# Altium schematic sheet LM25119_EVB.SchDoc(LM25119_EVB)
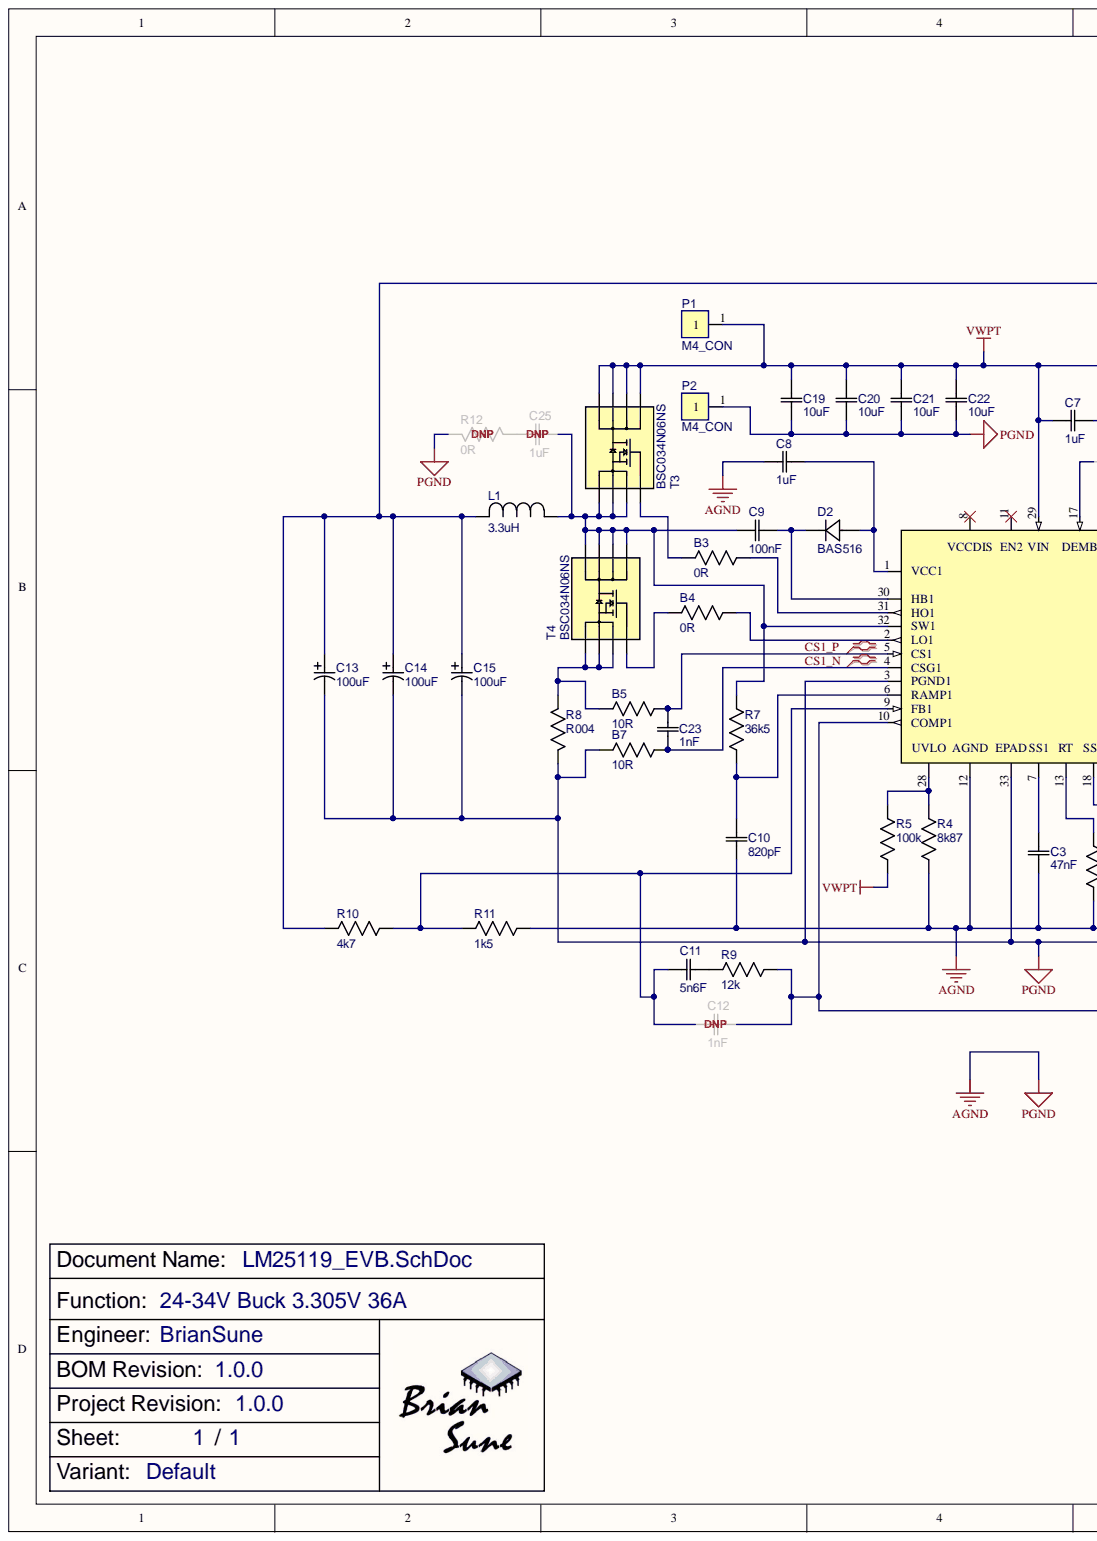
[diagram p0: LM25119_EVB.SchDoc, left]
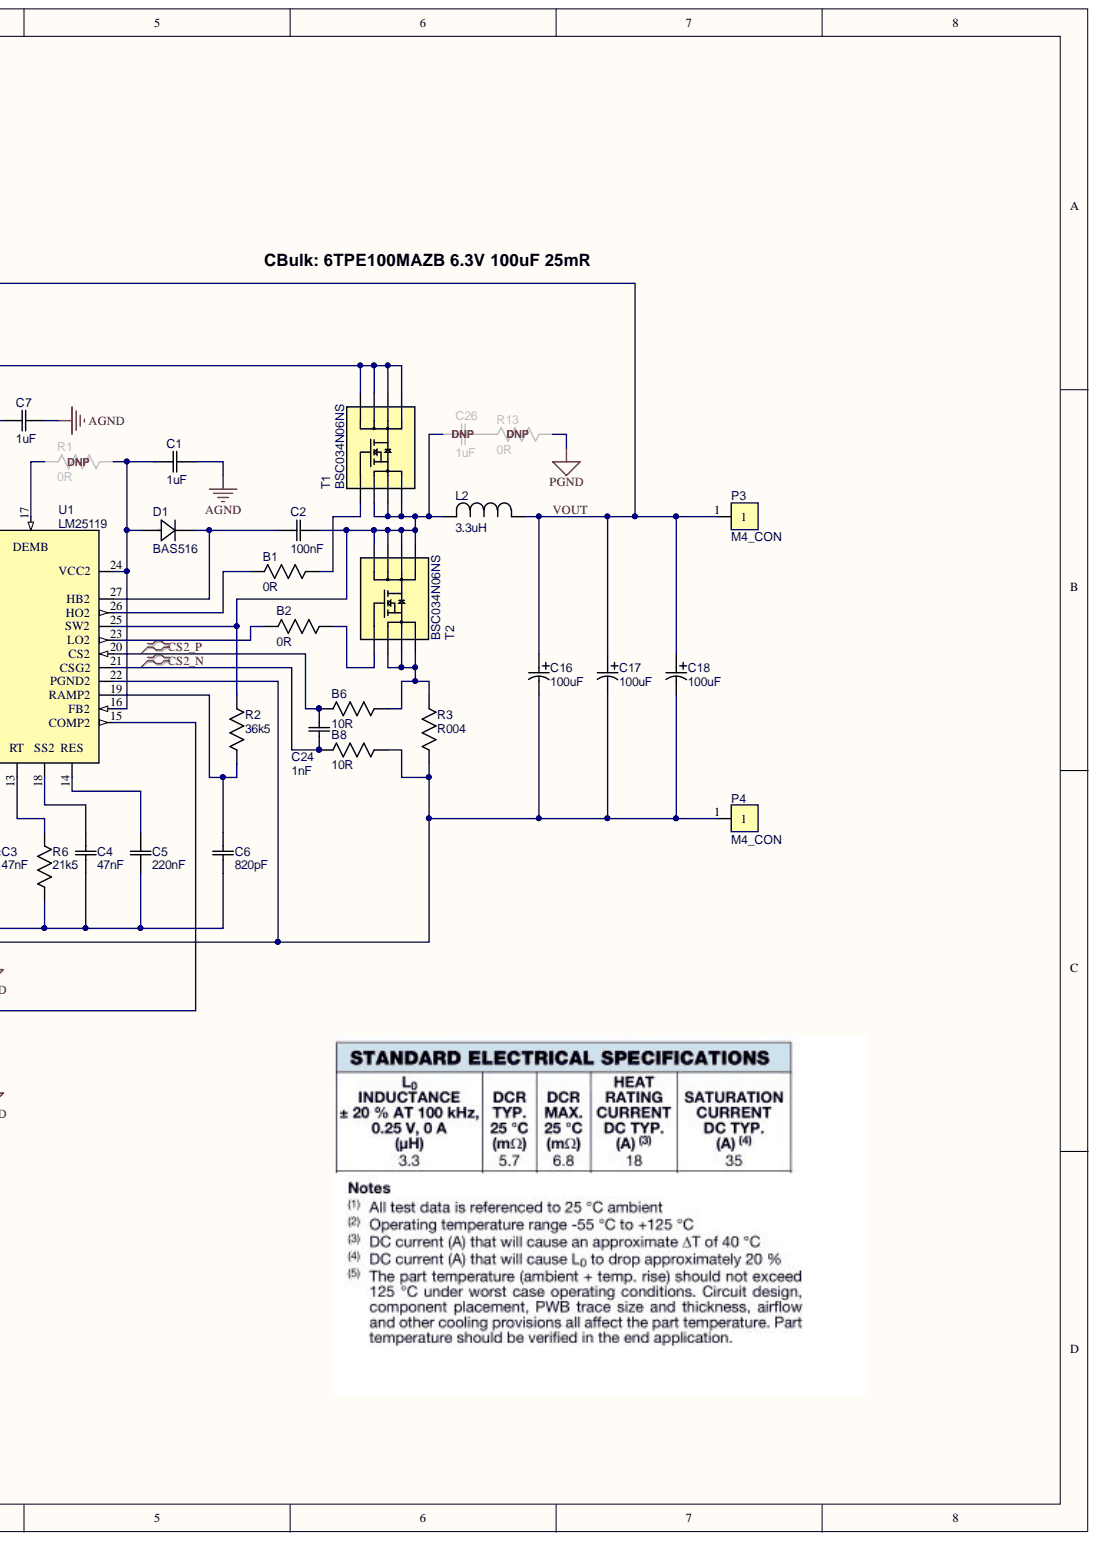
[diagram p1: LM25119_EVB.SchDoc, right]

## Parts
B1  0R  [0603]
B2  0R  [0603]
B3  0R  [0603]
B4  0R  [0603]
B5  10R  [0603]
B6  10R  [0603]
B7  10R  [0603]
B8  10R  [0603]
C1  1uF  [C0603]
C2  100nF  [C0603]
C3  47nF  [0402]
C4  47nF  [0402]
C5  220nF  [0402]
C6  820pF  [0402]
C7  1uF  [C0603]
C8  1uF  [C0603]
C9  100nF  [C0603]
C10  820pF  [0402]
C11  5n6F  [0402]
C12  1nF  [0402]
C13  100uF  [3528]
C14  100uF  [3528]
C15  100uF  [3528]
C16  100uF  [3528]
C17  100uF  [3528]
C18  100uF  [3528]
C19  10uF  [1210]
C20  10uF  [1210]
C21  10uF  [1210]
C22  10uF  [1210]
C23  1nF  [0402]
C24  1nF  [0402]
C25  1uF  [C0603]
C26  1uF  [C0603]
D1  BAS516  [SOD-523]
D2  BAS516  [SOD-523]
L1  3.3uH  [L126X]
L2  3.3uH  [L126X]
P1  M4_CON  [PCBComponent_1]
P2  M4_CON  [PCBComponent_1]
P3  M4_CON  [PCBComponent_1]
P4  M4_CON  [PCBComponent_1]
R1  0R  [0402]
R2  36k5  [0402]
R3  R004  [1225]
R4  8k87  [0402]
R5  100k  [0402]
R6  21k5  [0402]
R7  36k5  [0402]
R8  R004  [1225]
R9  12k  [0402]
R10  4k7  [0402]
R11  1k5  [0402]
R12  0R  [0603]
R13  0R  [0603]
T1  BSC034N06NS  [PQFNWB5x6]
T2  BSC034N06NS  [PQFNWB5x6]
T3  BSC034N06NS  [PQFNWB5x6]
T4  BSC034N06NS  [PQFNWB5x6]
U1  LM25119  [WQFN32]

## Nets
AGND: B7.1 B8.2 C1.2 C3.1 C4.1 C5.1 C6.1 C7.2 C8.2 C10.1 C13.2 C14.2 C15.2 C16.2 C17.2 C18.2 C19.1 C20.1 C21.1 C22.1 P2.1 P4.1 R3.1 R4.1 R6.1 R8.1 R11.1 R12.2 R13.2 U1.3(PGND1) U1.12 U1.22(PGND2) U1.33
VWPT: C7.1 C19.2 C20.2 C21.2 C22.2 P1.1 R5.2 T1.5 T1.6 T1.7 T1.8 T3.5 T3.6 T3.7 T3.8 U1.29
CS1_N: B7.2 C23.2 U1.4(CSG1)
CS1_P: B5.2 C23.1 U1.5(CS1)
CS2_N: B8.1 C24.2 U1.21(CSG2)
CS2_P: B6.1 C24.1 U1.20(CS2)
VOUT: C13.1 C14.1 C15.1 C16.1 C17.1 C18.1 L1.2 L2.1 P3.1 R10.1
NetB1_1: B1.1 U1.26(HO2)
NetB1_2: B1.2 T1.4
NetB2_1: B2.1 U1.23(LO2)
NetB2_2: B2.2 T2.4
NetB3_1: B3.1 T3.4
NetB3_2: B3.2 U1.31(HO1)
NetB4_1: B4.1 T4.4
NetB4_2: B4.2 U1.2(LO1)
NetB5_1: B5.1 R8.2 T4.1 T4.2 T4.3
NetB6_2: B6.2 R3.2 T2.1 T2.2 T2.3
NetC1_1: C1.1 D1.2 R1.1 U1.16(FB2) U1.24(VCC2)
NetC2_1: C2.1 D1.1 U1.27(HB2)
NetC2_2: C2.2 C26.1 L2.2 R2.2 T1.1 T1.2 T1.3 T2.5 T2.6 T2.7 T2.8 U1.25(SW2)
NetC3_2: C3.2 U1.7
NetC4_2: C4.2 U1.18
NetC5_2: C5.2 U1.14
NetC6_2: C6.2 R2.1 U1.19(RAMP2)
NetC8_1: C8.1 D2.2 U1.1(VCC1)
NetC9_1: C9.1 D2.1 U1.30(HB1)
NetC9_2: C9.2 C25.1 L1.1 R7.2 T3.1 T3.2 T3.3 T4.5 T4.6 T4.7 T4.8 U1.32(SW1)
NetC10_2: C10.2 R7.1 U1.6(RAMP1)
NetC11_1: C11.1 R9.2
NetC11_2: C11.2 C12.2 R10.2 R11.2 U1.9(FB1)
NetC12_1: C12.1 R9.1 U1.10(COMP1) U1.15(COMP2)
NetC25_2: C25.2 R12.1
NetC26_2: C26.2 R13.1
NetR1_2: R1.2 U1.17
NetR4_2: R4.2 R5.1 U1.28
NetR6_2: R6.2 U1.13(RT)

Not connected: U1 8 11

## Library data of the parts
B1-B4 R12 R13 = 0R: 0R 1/10W +/-1%; Mfr.: UNIOHM; Price: 0.016; Mfr. No: 0R; Library Name: R0603.IntLib; ComponentLink1URL: https://item.taobao.com/item.htm?spm=a1z10.3-c-s.w4002-24706531953.10.66736a4boyPN1N&id=584519288165; ComponentLink1Description: taobao; Component Kind: Standard
B5-B8 = ARG03DTC0100: 10R 1/10W +/-0.5%; Mfr.: Viking; Price: 0.044; Mfr. No: ARG03DTC0100; Library Name: R0603.IntLib; ComponentLink1URL: https://item.taobao.com/item.htm?spm=a1z09.2.0.0.6e162e8dlKCeMq&id=632526730087&_u=c10quk0k836f; ComponentLink1Description: taobao; Component Kind: Standard
C1 C7 C8 C25 C26 = OUDEIBUN_1uF: 0603 1UF 16V 10% 105K X5R; Price: 0.03; Mfr. No: 105K; Mfr.: OUDEIBUN; Library Name: C0603.IntLib; ComponentLink1URL: https://detail.tmall.com/item.htm?abbucket=11&id=610883455002&rn=96f7b0dde361d4c3b62eb7ab1af2da05&skuId=4292434832790&spm=a1z10.3-b.w4011-22147617817.48.46817d1eD6aiZS; ComponentLink1Description: taobao; Component Kind: Standard
C2 C9 = OUDEIBUN_100nF: 0603 100NF 50V 10% 104K X7R; Price: 0.025; Mfr. No: 104K; Mfr.: OUDEIBUN; Library Name: C0603.IntLib; ComponentLink1URL: https://detail.tmall.com/item.htm?_u=p10quk0k2f35&id=610651934672&spm=a1z09.2.0.0.77b12e8d9iVia0&skuId=4463522550238; ComponentLink1Description: taobao; Component Kind: Standard
C3 C4 = OBEIDUN_47nF: 0402 47nF 473K 16V X7R; Price: 0.03; Mfr. No: 473K; Mfr.: OBEIDUN; Library Name: C0402.IntLib; ComponentLink1URL: https://detail.tmall.com/item.htm?abbucket=13&id=610175557402&rn=d6bd0513a8f4c3e4804911bb922d3d96&skuId=4463850175314&spm=a1z10.3-b.w4011-22147617817.39.63c07d1eHWIor4; ComponentLink1Description: taobao; Component Kind: Standard
C5 = OBEIDUN_220nF: 0402 220nF 224K  50V X7R; Price: 0.03; Mfr. No: 224K; Mfr.: OBEIDUN; Library Name: C0402.IntLib; ComponentLink1URL: https://detail.tmall.com/item.htm?abbucket=11&id=610639075445&rn=c45cf579a90ea6adc9060b093ff18dcd&skuId=4463795831944&spm=a312a.7700824.w4011-22147617817.53.51a47d1eimiUpx; ComponentLink1Description: taobao; Component Kind: Standard
C6 C10 = OBEIDUN_680pF: 680pF 50V 100V +/-5% 681J COG; Price: 0.02; Mfr. No: 681J; Mfr.: OBEIDUN; Library Name: C0402.IntLib; ComponentLink1URL: https://detail.tmall.com/item.htm?abbucket=13&id=609631499278&rn=f5bd684ac3f51c46f888ad99ccd96ebe&spm=a1z10.3-b.w4011-22147617817.52.66d87d1eD7edtj&skuId=4450047134670; ComponentLink1Description: taobao; Component Kind: Standard
C11 = OBEIDUN_5n6F: 0402 562K 5.6NF 50V X7R; Price: 0.015; Mfr. No: 562K; Mfr.: OBEIDUN; Library Name: C0402.IntLib; ComponentLink1URL: https://detail.tmall.com/item.htm?_u=i10quk0kb5ea&id=609907244741&spm=a1z09.2.0.0.485e2e8ddN1cee; ComponentLink1Description: taobao; Component Kind: Standard
C12 = OBEIDUN_22pF: 0402 22pF 50V 20J COG NPO; Mfr. No: 220J; Price: 0.02; Mfr.: OBEIDUN; Library Name: C0402.IntLib; ComponentLink1URL: https://detail.tmall.com/item.htm?abbucket=13&id=609430597564&rn=a1c8a15a7d6b2f90e38db74694a5b856&spm=a1z10.3-b.w4011-22147617817.40.15037d1etTZYEz&skuId=4280449596223; ComponentLink1Description: taobao; Component Kind: Standard
C13-C18 = 6TPE100MPB: 6TPE100MAZB 6.3V 100uF ESR 25mR; Mfr.: Auwah; Price: 1.0; Mfr. No: 6TPE100MAZB; Library Name: C3528.IntLib; ComponentLink1URL: https://detail.tmall.com/item.htm?id=608667689658&spm=a1z0d.6639537/tb2.1997196601.4.461a748465LZBL&skuId=4600334325022; ComponentLink1Description: taobao; Component Kind: Standard
C19-C22 = OUBEIDUN_106_X7R: 1210 10UF 106K 100V X7R; Mfr. No: 10uF/100V; Price: 0.6; Mfr.: OUBEIDUN; Library Name: C1210.IntLib; ComponentLink1URL: https://detail.tmall.com/item.htm?_u=q10quk0k91e6&id=610720536810&spm=a1z09.2.0.0.680e2e8dgSrizI&skuId=4470822090146; ComponentLink1Description: taobao; Component Kind: Standard
C23 C24 = RAINPOLE_2n2F: RAINPOLE 0402 2.2nF 5% 50V NPO; Mfr. No: 222J; Price: 0.04; Mfr.: RAINPOLE; Library Name: C0402.IntLib; ComponentLink1URL: https://detail.tmall.com/item.htm?abbucket=11&id=577480004200&rn=e81fc7a7675914d6e9f82eba46a12cbb&skuId=3986690931935&spm=a1z10.3-b-s.w4011-12364813249.37.6d9c1ed6XWLE9d; ComponentLink1Description: taobao; Component Kind: Standard
D1 D2 = OBEIDUN_BAS516: SOD-523 100V/250mA; Mfr. No: BAS516,61; Price: 0.14; Mfr.: OBEIDUN; Library Name: BAS516.IntLib; ComponentLink1URL: https://detail.tmall.com/item.htm?abbucket=11&id=627344864030&rn=9e03fb0dc0c82be40d648479edaa9265&skuId=4457291933929&spm=a1z10.3-b.w4011-22147617817.46.572e7d1eRfO8kn; ComponentLink1Description: taobao; Component Kind: Standard
L1 L2 = L1260_332: HC1260 3R3M; Mfr. No: HC1260_3R3; Mfr.: Xing Guangzhou; Price: 1.2; Library Name: L12x0.IntLib; ComponentLink1URL: https://item.taobao.com/item.htm?spm=a1z09.2.0.0.57072e8dYkUt8H&id=10840816607&_u=410quk0k837d; ComponentLink1Description: taobao; Component Kind: Standard
P1-P4 = M4_CON: Mfr. No: PCB-10-4; Mfr.: LFX; Price: 0.41; Library Name: M4_CON.IntLib; Description: M4_CON; ComponentLink1URL: https://item.taobao.com/item.htm?spm=a1z09.2.0.0.45712e8dxvwLo5&id=531030902005&_u=s10quk0k994a; ComponentLink1Description: taobao; Component Kind: Standard
R1 = OUDEIBUN_0R: OUDEIBUN  0R 1% 1/16W; Price: 0.02; Mfr. No: 0R; Mfr.: OUDEIBUN; Library Name: R0402.IntLib; ComponentLink1URL: https://detail.tmall.com/item.htm?abbucket=11&id=609078082053&rn=dd22835191e181ef543cf6f0827e8de3&spm=a1z10.3-b.w4011-22147617817.40.1e787d1eBkSpf5&skuId=4447040574382; ComponentLink1Description: taobao; Component Kind: Standard
R2 R7 = OUDEIBUN_36k5: OUDEIBUN  36k5 1% 1/16W; Price: 0.02; Mfr. No: 36k5; Mfr.: OUDEIBUN; Library Name: R0402.IntLib; ComponentLink1URL: https://detail.tmall.com/item.htm?abbucket=11&id=656599902463&rn=b271eb3836211a3eb1da72e116a619f1&skuId=4912168475534&spm=a1z10.3-b.w4011-22147617817.19.bd537d1eRNyfmb; ComponentLink1Description: taobao; Component Kind: Standard
R3 R8 = VVIKING_R004_3W: Price: 1.5; Mfr. No: VVIKING_R004_3W; Mfr.: VVIKING; Library Name: R1225.IntLib; Description: VVIKING_R004_3W; ComponentLink1URL: https://item.taobao.com/item.htm?_u=110quk0k2b5e&id=630534228451&skuId=4663993838964&spm=a1z09.2.0.0.57392e8dDftXgF; ComponentLink1Description: taobao; Component Kind: Standard
R4 = UNIOHM_8k87: UNIOHM  8k87 1% 1/16W; Mfr. No: 0402WGF8871TCE; Price: 0.012; Mfr.: UNIOHM; Library Name: R0402.IntLib; ComponentLink1URL: https://item.taobao.com/item.htm?spm=a1z09.2.0.0.526a2e8dtHz0un&id=605027116229&_u=110quk0kf99f; ComponentLink1Description: taobao; Component Kind: Standard
R5 = UNIOHM_100k: UNIOHM  1% 100k; Price: 0.012; Mfr. No: 100k; Mfr.: UNIOHM; Library Name: R0402.IntLib; ComponentLink1URL: https://item.taobao.com/item.htm?spm=a1z09.2.0.0.44de2e8d3IDpt5&id=525633701805&_u=o10quk0k9fd0; ComponentLink1Description: taobao; Component Kind: Standard
R6 = OUDEIBUN_21k5: OUDEIBUN  21k5 1% 1/16W; Price: 0.02; Mfr. No: 21k5; Mfr.: OUDEIBUN; Library Name: R0402.IntLib; ComponentLink1URL: https://detail.tmall.com/item.htm?_u=310quk0k3cbb&id=656218957556&spm=a1z09.2.0.0.d5442e8dvaKsoM&skuId=4912158475130; ComponentLink1Description: taobao; Component Kind: Standard
R9 = OUDEIBUN_12k: OUDEIBUN  12k 1% 1/16W; Price: 0.02; Mfr. No: 12k; Mfr.: OUDEIBUN; Library Name: R0402.IntLib; ComponentLink1URL: https://detail.tmall.com/item.htm?abbucket=11&id=655765932456&rn=02d1aad3326fb9002d0e9ee11440ad53&skuId=4733468228268&spm=a1z10.3-b.w4011-22147617817.23.a0227d1efWkiz2; ComponentLink1Description: taobao; Component Kind: Standard
R10 = OUDEIBUN_4k7: OUDEIBUN  4k7 1% 1/16W; Price: 0.02; Mfr. No: 4k7; Mfr.: OUDEIBUN; Library Name: R0402.IntLib; ComponentLink1URL: https://detail.tmall.com/item.htm?abbucket=11&id=609306247616&rn=b12b173379d08c9da521548aa8f3873c&spm=a1z10.3-b.w4011-22147617817.66.27437d1eyVjB3l&skuId=4279727269027; ComponentLink1Description: taobao; Component Kind: Standard
R11 = OUDEIBUN_1k5: OUDEIBUN  1% 1k5; Price: 0.02; Mfr. No: 1k5; Mfr.: OUDEIBUN; Library Name: R0402.IntLib; ComponentLink1URL: https://detail.tmall.com/item.htm?abbucket=11&id=609080898470&rn=e8ac5f428b57ca8c5f4fd2285344b596&spm=a1z10.3-b.w4011-22147617817.56.4da07d1eK35JhM&skuId=4276063084040; ComponentLink1Description: taobao; Component Kind: Standard
T1-T4 = BSC034N06NS: MPower Transistor, 60V; Mfr.: Infineon Technologies; Price: 4.5; Mfr. No: BSC034N06NS; Library Name: MOS_PQFNWB5x6.IntLib; ComponentLink1URL: https://detail.tmall.com/item.htm?abbucket=12&id=712516353118&ns=1&spm=a21n57.1.0.0.5a70523ceCAuo0&skuId=4992670365636; ComponentLink1Description: taobao; Component Kind: Standard
U1 = LM25119: Wide Input Range, Dual Synchronous Buck Controller; Mfr. No: LM25119PSQ; Mfr.: Texas Instruments; Price: 10.0; Library Name: LM25119.IntLib; ComponentLink1URL: https://item.taobao.com/item.htm?spm=a1z09.2.0.0.57392e8dDftXgF&id=750183520652&_u=110quk0kdafb; ComponentLink1Description: taobao; Component Kind: Standard
